# Altium schematic sheet ESP Programming_Top.SchDoc
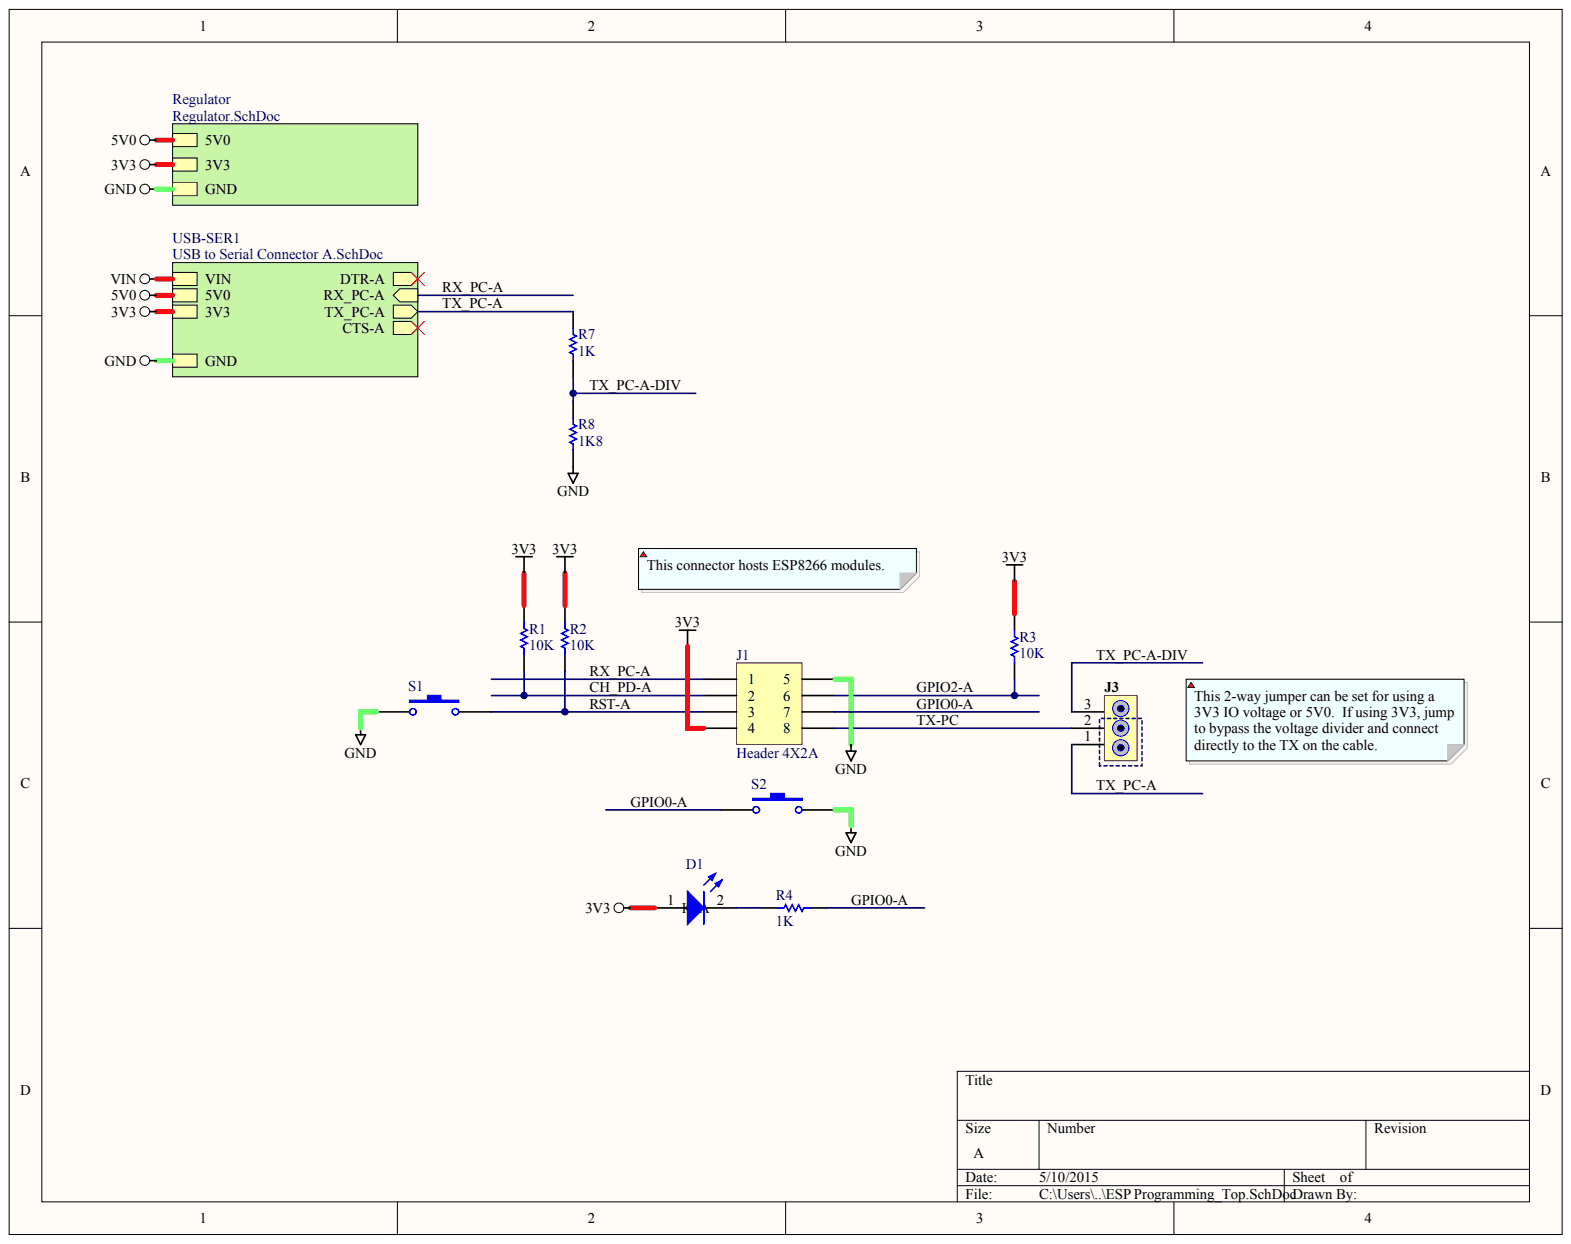
[diagram p0: ESP Programming_Top.SchDoc]

## Parts
D1  LED2  (value not drawn)
J1  Header 4X2A
J3  3-WAY JUMPER  (value not drawn)
R1  value 10K  (hidden comment: Res1)
R2  value 10K  (hidden comment: Res1)
R3  value 10K  (hidden comment: Res1)
R4  value 1K  (hidden comment: Res1)
R7  value 1K  (hidden comment: Res1)
R8  value 1K8  (hidden comment: Res1)
S1  SW-PB  (value not drawn)
S2  SW-PB  (value not drawn)

## Nets
3V3: D1.1 J1.4 R1.2 R2.2 R3.2
GND: J1.5 R8.1 S1.1 S2.2
CH_PD-A: J1.2 R1.1
GPIO0-A: J1.7 R4.1 S2.1
GPIO2-A: J1.6 R3.1
RST-A: J1.3 R2.1 S1.2
RX_PC-A: J1.1
TX-PC: J1.8 J3.2
TX_PC-A: J3.1 R7.2
TX_PC-A-DIV: J3.3 R7.1 R8.2
NetD1_2: D1.2 R4.2
5V0:
CTS-A:
DTR-A:
VIN:

## Library data of the parts
D1 J1 J3 R1-R4 R7 R8 S1 S2 = (no symbol name)
  D1: Published: 8-Jun-2000; LatestRevisionDate: 17-Jul-2002; LatestRevisionNote: Re-released for DXP Platform.; PackageVersion: Feb-2002; PackageReference: 3.2X1.6X1.1; PackageDescription: SM LED; 2 Flat Leads
  J1: LatestRevisionDate: 17-Jul-2002; LatestRevisionNote: Re-released for DXP Platform.
  R1-R4 R7 R8: Published: 8-Jun-2000; LatestRevisionDate: 17-Jul-2002; LatestRevisionNote: Re-released for DXP Platform.; PackageReference: AXIAL-0.3; PackageDescription: Axial Device, Thru-Hole; 2 Leads; 0.3 in Pin Spacing
  S1 S2: Published: 24-Mar-1999; LatestRevisionDate: 17-Jul-2002; LatestRevisionNote: Re-released for DXP Platform.; PackageReference: SPST-2; PackageDescription: Switch; 2 Leads
  D1 J1 R1-R4 R7 R8 S1 S2: Publisher: Altium Limited
